# Altium schematic sheet can_12V_step_Ivan.SchDoc
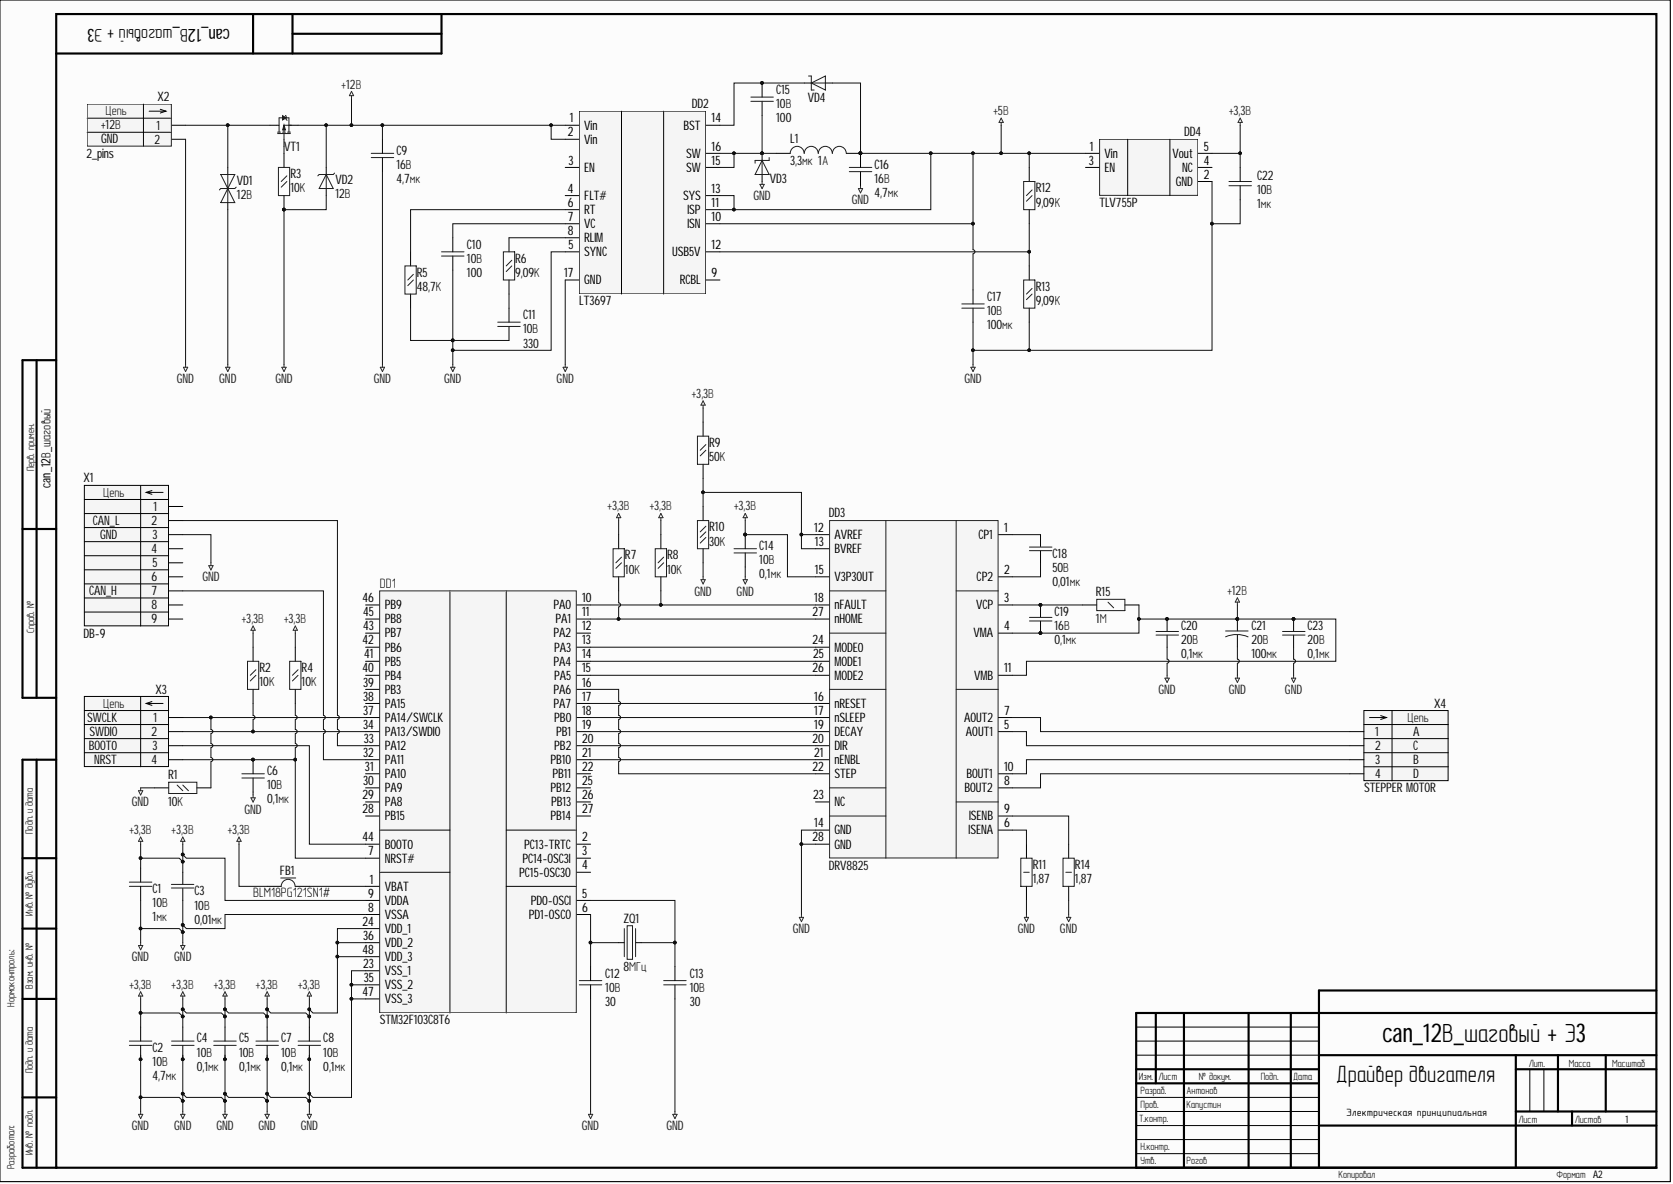
[diagram p0: can_12V_step_Ivan.SchDoc]

## Parts
C1  Capacitance: 1мк  Voltage: 10В  [CH0201C]  (hidden comment: Capacitor)
C2  Capacitance: 4,7мк  Voltage: 10В  [CH0402C]  (hidden comment: Capacitor)
C3  Capacitance: 0,01мк  Voltage: 10В  [CH0402C]  (hidden comment: Capacitor)
C4  Voltage: 10В  [CH0402C]  (hidden comment: Capacitor)
C5  Capacitance: 0,1мк  Voltage: 10В  [CH0402C]  (hidden comment: Capacitor)
C6  Capacitance: 0,1мк  Voltage: 10В  [CH0402C]  (hidden comment: Capacitor)
C7  Capacitance: 0,1мк  Voltage: 10В  [CH0402C]  (hidden comment: Capacitor)
C8  Capacitance: 0,1мк  Voltage: 10В  [CH0402C]  (hidden comment: Capacitor)
C9  Capacitance: 4,7мк  Voltage: 16В  [CH0603C]  (hidden comment: Capacitor)
C10  Voltage: 10В  [CH0201C]  (hidden comment: Capacitor)
C11  Voltage: 10В  [CH0402C]  (hidden comment: Capacitor)
C12  Voltage: 10В  [CH0201C]  (hidden comment: Capacitor)
C13  Voltage: 10В  [CH0201C]  (hidden comment: Capacitor)
C14  Capacitance: 0,1мк  Voltage: 10В  [CH0402C]  (hidden comment: Capacitor)
C15  Voltage: 10В  [CH0201C]  (hidden comment: Capacitor)
C16  Capacitance: 4,7мк  Voltage: 16В  [CH0603C]  (hidden comment: Capacitor)
C17  Capacitance: 100мк  Voltage: 10В  [CH1206C]  (hidden comment: Capacitor)
C18  Capacitance: 0,01мк  Voltage: 50В  [CH0402C]  (hidden comment: Capacitor)
C19  Capacitance: 0,1мк  Voltage: 16В  [CH0402C]  (hidden comment: Capacitor)
C20  Capacitance: 0,1мк  Voltage: 20В  [CH0805C]  (hidden comment: Capacitor)
C21  Capacitance: 100мк  Voltage: 20В  [RB5-10.5]  (hidden comment: Capacitor_Passing)
C22  Capacitance: 1мк  Voltage: 10В  [CH0201C]  (hidden comment: Capacitor)
C23  Capacitance: 0,1мк  Voltage: 20В  [CH0805C]  (hidden comment: Capacitor)
DD1  STM32F103C8T6  [STM32F103 48pins]
DD2  LT3697  [LT3697]
DD3  DRV8825  [DRV8825]
DD4  TLV755P  [SOT23-5]
FB1  Model: BLM18PG121SN1#  [CH0603L]  (hidden comment: Ferrite bead)
L1  Inductance: 3,3мк  Max current: 1А  [CH0805L]
R1  Impedance: 10К  [CH0805R]  (hidden comment: Res_0.125)
R2  Impedance: 10К  [CH0805R]  (hidden comment: Res_0.125)
R3  Impedance: 10К  [CH0402R]  (hidden comment: Res_0.125)
R4  Impedance: 10К  [CH0805R]  (hidden comment: Res_0.125)
R5  Impedance: 48,7К  [CH0805R]  (hidden comment: Res_0.125)
R6  Impedance: 9,09К  [CH0805R]  (hidden comment: Res_0.125)
R7  Impedance: 10К  [CH0805R]  (hidden comment: Res_0.125)
R8  Impedance: 10К  [CH0805R]  (hidden comment: Res_0.125)
R9  Impedance: 50К  [CH0603R]  (hidden comment: Res_0.125)
R10  Impedance: 30К  [CH0201R]  (hidden comment: Res_0.05)
R11  Impedance: 1,87  [CH0603R]  (hidden comment: Res_1)
R12  Impedance: 9,09К  [CH0805R]  (hidden comment: Res_0.125)
R13  Impedance: 9,09К  [CH0805R]  (hidden comment: Res_0.125)
R14  Impedance: 1,87  [CH0603R]  (hidden comment: Res_1)
R15  Impedance: 1М  [CH0805R]  (hidden comment: Res_0.5)
VD1  Clamping Voltage: 12В  [CH0201L]  (hidden comment: TVS-diod_simmetrical)
VD2  Clamping_Voltage: 12В  [DO214AA]  (hidden comment: TVS-diod_directed)
VD3  Diod Schottky  [DO214AA]  (value not drawn)
VD4  Diod Schottky  [SOT23_132]  (value not drawn)
VT1  (no value)  [SOT23_132]
X1  DB-9  2: CAN_L  3: GND  7: CAN_H  [CON: DB-9F]
X2  2_pins  Vcc: +12В  [CON: 163-179PH-EX]
X3  1: SWCLK  2: SWDIO  3: BOOT0  4: NRST  [CON: CWF-4R]  (hidden comment: 4_pins)
X4  STEPPER MOTOR  1: A  2: C  3: B  4: D  [CON: CWF-4R]
ZQ1  Freq: 8МГц  [OSC: 5032]  (hidden comment: Oscillator)

## Nets
+3,3В: C1.2 C2.2 C3.1 C4.1 C5.1 C7.1 C8.1 C14.1 C22.1 DD1.9(VDDA) DD1.24(VDD_1) DD1.36(VDD_2) DD1.48(VDD_3) DD3.15(V3P3OUT) DD4.5(Vout) FB1.1 R2.1 R4.1 R7.1 R8.1 R9.2
+5В: C16.1 C17.1 DD2.10(ISN) DD2.11(ISP) DD2.13(SYS) DD4.1(Vin) L1.2 R12.1 VD4.1
+12В: C9.1 C19.1 C20.2 C21.1 C23.2 DD2.1(Vin) DD2.2(Vin) DD3.4(VMA) DD3.11(VMB) R15.1 VD2.2 VT1.3
GND: C1.1 C2.1 C3.2 C4.2 C5.2 C6.2 C7.2 C8.2 C9.2 C10.2 C11.2 C12.2 C13.2 C14.2 C16.2 C17.2 C20.1 C21.2 C22.2 C23.1 DD1.8(VSSA) DD1.23(VSS_1) DD1.35(VSS_2) DD1.47(VSS_3) DD2.5(SYNC) DD2.17(GND) DD3.14(GND) DD3.28(GND) DD4.2(GND) R1.1 R3.1 R5.2 R10.1 R11.1 R13.2 R14.1 VD1.1 VD2.1 VD3.1 X1.3 X2.2
NetC6_1: C6.1 DD1.7(NRST#) R4.2 X3.4
NetC10_1: C10.1 DD2.7(VC)
NetC11_1: C11.1 R6.2
NetC12_1: C12.1 DD1.6(PD1-OSCO) ZQ1.1
NetC13_1: C13.1 DD1.5(PD0-OSCI) ZQ1.3
NetC15_1: C15.1 DD2.14(BST) VD4.2
NetC15_2: C15.2 DD2.15(SW) DD2.16(SW) L1.1 VD3.2
NetC18_1: C18.1 DD3.2(CP2)
NetC18_2: C18.2 DD3.1(CP1)
NetC19_2: C19.2 DD3.3(VCP) R15.2
NetDD1_1: DD1.1(VBAT) FB1.2
NetDD1_10: DD1.10(PA0) DD3.18(nFAULT) R8.2
NetDD1_11: DD1.11(PA1) DD3.27(nHOME) R7.2
NetDD1_13: DD1.13(PA3) DD3.24(MODE0)
NetDD1_14: DD1.14(PA4) DD3.25(MODE1)
NetDD1_15: DD1.15(PA5) DD3.26(MODE2)
NetDD1_16: DD1.16(PA6) DD3.22(STEP)
NetDD1_17: DD1.17(PA7) DD3.16(nRESET)
NetDD1_18: DD1.18(PB0) DD3.17(nSLEEP)
NetDD1_19: DD1.19(PB1) DD3.19(DECAY)
NetDD1_20: DD1.20(PB2) DD3.20(DIR)
NetDD1_21: DD1.21(PB10) DD3.21(nENBL)
NetDD1_32: DD1.32(PA11) X1.7
NetDD1_33: DD1.33(PA12) X1.2
NetDD1_34: DD1.34(PA13/SWDIO) R2.2 X3.2
NetDD1_37: DD1.37(PA14/SWCLK) R1.2 X3.1
NetDD1_44: DD1.44(BOOT0) X3.3
NetDD2_6: DD2.6(RT) R5.1
NetDD2_8: DD2.8(RLIM) R6.1
NetDD2_12: DD2.12(USB5V) R12.2 R13.1
NetDD3_5: DD3.5(AOUT1) X4.2
NetDD3_6: DD3.6(ISENA) R11.2
NetDD3_7: DD3.7(AOUT2) X4.1
NetDD3_8: DD3.8(BOUT2) X4.4
NetDD3_9: DD3.9(ISENB) R14.2
NetDD3_10: DD3.10(BOUT1) X4.3
NetDD3_12: DD3.12(AVREF) DD3.13(BVREF) R9.1 R10.2
NetR3_2: R3.2 VT1.1
NetVD1_2: VD1.2 VT1.2 X2.1

Not connected: DD1 2(PC13-TRTC) 3(PC14-OSC3I) 4(PC15-OSC3O) 12(PA2) 22(PB11) 25(PB12) 26(PB13) 27(PB14) 28(PB15) 29(PA8) 30(PA9) 31(PA10) 38(PA15) 39(PB3) 40(PB4) 41(PB5) 42(PB6) 43(PB7) 45(PB8) 46(PB9); DD2 3(EN) 4(FLT#) 9(RCBL); DD3 23(NC); DD4 3(EN) 4(NC); X1 1 4 5 6 8 9

## Library data of the parts
C1 C22 = Capacitor: Manufacture: Murata Electronics; Model: GRM033C81A105ME05E; Case: 0201; Component Kind: Standard; HelpURL: https://ru.mouser.com/ProductDetail/Murata-Electronics/GRM033C81A105ME05E?qs=sGAEpiMZZMs0AnBnWHyRQN7%2fAA2D2lPPXfhIkJ3ChWM%252bNp28BKy3Ug%3d%3d; Library Name: GOST_Capacitors.SchLib
C2 = Capacitor: Manufacture: Murata Electronics; Model: ZRB15XR61A475KE01D; Case: 0402; Component Kind: Standard; HelpURL: https://ru.mouser.com/ProductDetail/Murata-Electronics/ZRB15XR61A475KE01D?qs=sGAEpiMZZMs0AnBnWHyRQO9VYadaKTO%252beZnE9zFUsbv4FmCibz4ZfQ%3d%3d; Library Name: GOST_Capacitors.SchLib
C3 = Capacitor: Manufacture: Yageo; Model: CC0402MRX7R6BB103; Case: 0402; Component Kind: Standard; HelpURL: https://ru.mouser.com/ProductDetail/Yageo/CC0402MRX7R6BB103?qs=sGAEpiMZZMs0AnBnWHyRQCF1lTWZ1EBLC11KxQK9NtY%3D; Library Name: GOST_Capacitors.SchLib
C4 = Capacitor: Manufacture: AVX; Capacitance: 0,1мк; Model: 0402ZD103KAT2A; Case: 0402; Component Kind: Standard; HelpURL: https://ru.mouser.com/ProductDetail/AVX/0402ZD103KAT2A?qs=sGAEpiMZZMs0AnBnWHyRQKdiqyDPVQdAu74W%2fwwRq8A%3d; Library Name: GOST_Capacitors.SchLib
C5-C8 C14 = Capacitor: Manufacture: AVX; Model: 0402ZD103KAT2A; Case: 0402; Component Kind: Standard; HelpURL: https://ru.mouser.com/ProductDetail/AVX/0402ZD103KAT2A?qs=sGAEpiMZZMs0AnBnWHyRQKdiqyDPVQdAu74W%2fwwRq8A%3d; Library Name: GOST_Capacitors.SchLib
C9 C16 = Capacitor: Manufacture: Murata Electronics; Model: GRM188R61C475KAAJD; Case: 0603; Component Kind: Standard; HelpURL: https://ru.mouser.com/ProductDetail/Murata-Electronics/GRM188R61C475KAAJD?qs=sGAEpiMZZMs0AnBnWHyRQHS%2f3XE0oMwxE3tFP4oDIiY%3d; Library Name: GOST_Capacitors.SchLib
C10 C15 = Capacitor: Manufacture: KEMET; Capacitance: 100; Model: C0201C101K8GACTU; Case: 0201; Component Kind: Standard; HelpURL: https://ru.mouser.com/ProductDetail/KEMET/C0201C101K8GACTU?qs=sGAEpiMZZMs0AnBnWHyRQB5KqWiNAtmEn9gsTWOdKS%252b7RrFbxqtALA%3d%3d; Library Name: GOST_Capacitors.SchLib
C11 = Capacitor: Manufacture: Vishay / Vitramon; Capacitance: 330; Model: VJ0402Y331MXQCW1BC; Case: 0402; Component Kind: Standard; HelpURL: https://ru.mouser.com/ProductDetail/Vishay-Vitramon/VJ0402Y331MXQCW1BC?qs=sGAEpiMZZMs0AnBnWHyRQHefeAIkSpb%2fKjIwGvKZvs8%3d; Library Name: GOST_Capacitors.SchLib
C12 C13 = Capacitor: Manufacture: KEMET; Capacitance: 30; Model: CBR02C308B8GAC; Case: 0201; Component Kind: Standard; HelpURL: https://ru.mouser.com/ProductDetail/KEMET/CBR02C308B8GAC?qs=sGAEpiMZZMs0AnBnWHyRQKJCfzG%2fi9wHnix1yOwfSPhOUzhoHh%252bTpQ%3d%3d; Library Name: GOST_Capacitors.SchLib
C17 = Capacitor: Manufacture: TDK; Model: C3216X5R1A107M160AC; Case: 1206; Component Kind: Standard; HelpURL: https://ru.mouser.com/ProductDetail/TDK/C3216X5R1A107M160AC?qs=sGAEpiMZZMs0AnBnWHyRQEWjzA2rN6H9eWVfGykJv7Q%3d; Library Name: GOST_Capacitors.SchLib
C18 = Capacitor: Manufacture: Yageo; Model: AC0402KRX7R9BB103; Case: 0402; Component Kind: Standard; HelpURL: https://ru.mouser.com/ProductDetail/Yageo/AC0402KRX7R9BB103?qs=sGAEpiMZZMs0AnBnWHyRQBZcF7Vf94SCHXtsGWcqG94cFjQPe22zAg%3D%3D; Library Name: GOST_Capacitors.SchLib
C19 = Capacitor: Manufacture: Yageo; Model: CC0402KPX5R7BB104; Case: 0402; Component Kind: Standard; HelpURL: https://ru.mouser.com/ProductDetail/Yageo/CC0402KPX5R7BB104?qs=sGAEpiMZZMs0AnBnWHyRQBZcF7Vf94SC0cALCY4FDZWppIvzFqHKwA%3D%3D; Library Name: GOST_Capacitors.SchLib
C20 C23 = Capacitor: Manufacture: Vishay / Sprague; Model: TMCP1D104MTRF; Case: 0805; Component Kind: Standard; HelpURL: https://ru.mouser.com/ProductDetail/Vishay-Sprague/TMCP1D104MTRF?qs=sGAEpiMZZMsh%252B1woXyUXj%252Bp8lp8l%2FqQ2aRrDnwXAyQE%3D; Library Name: GOST_Capacitors.SchLib
C21 = Capacitor_Passing: Manufacture: KEMET; Model: A755KS107M1DAAE020; Case: Bulk 8х12 mm; Component Kind: Standard; HelpURL: https://ru.mouser.com/ProductDetail/KEMET/A755KS107M1DAAE020?qs=sGAEpiMZZMsh%252B1woXyUXj9o1%2FkKtBj0MuWI8g1mDmRQ%3D; Library Name: GOST_Capacitors.SchLib
DD1 = STM32: Manufacture: STMicroelectronics; Case: LQFP48; Component Kind: Standard; HelpURL: https://www.st.com/en/microcontrollers-microprocessors/stm32f103c8.html; Model: STM32F103C8T6; Library Name: GOST_lib_devices.SchLib
DD2 = LT3697: 6~18 to 5; Manufacture: Analog Devices; Component Kind: Standard; HelpURL: https://www.analog.com/en/products/lt3697.html; Model: LT3697; Library Name: GOST_lib_devices.SchLib
DD3 = DRV8825: Manufacture: Texas Instruments; Case: TSSOP; Component Kind: Standard; HelpURL: http://www.ti.com/product/DRV8825; Model: DRV8825; Library Name: GOST_lib_devices.SchLib
DD4 = LDO +3.3V: Manufacture: Texas Instruments; Case: SOT-23; Component Kind: Standard; HelpUrL: http://www.ti.com/product/TLV755P?keyMatch=TLV755P&tisearch=Search-EN-Everything; Model: TLV755P; Library Name: GOST_lib_devices.SchLib
FB1 = Ferrite bead: Manufacture: murata; Impedance: 120; Max current: 2A; Case: 0603; Component Kind: Standard; HelpURL: https://www.murata.com/en-us/products/productdetail?partno=BLM18PG121SN1%23; Library Name: GOST_Inductors.SchLib
L1 = Inductor: Manufacture: Murata Electronics; Impedance: 150м; Model: LQM21PZ3R3MGRD; Case: 0805; Component Kind: Standard; HelpURL: https://ru.mouser.com/ProductDetail/Murata-Electronics/LQM21PZ3R3MGRD?qs=sGAEpiMZZMsg%252by3WlYCkU2iuTbKTbm6Zxs6Ix4GkUPA%3d; Library Name: GOST_Inductors.SchLib
R1 R2 R4 R6-R8 R12 R13 = Res_0.125: Manufacture: Panasonic Industrial Devices; Model: ERJ-6ENF9091V; Case: 0805; Component Kind: Standard; HelpURL: https://ru.mouser.com/ProductDetail/Panasonic-Industrial-Devices/ERJ-6ENF9091V?qs=sGAEpiMZZMvdGkrng054tx7%2f6%252bNA3LAJZkxjwUnWjWM%3d; Library Name: GOST_Resistors.SchLib
R3 = Res_0.125: Manufacture: Yageo; Model: AC0402FR-7W10KL; Case: 0402; Component Kind: Standard; HelpURL: https://ru.mouser.com/ProductDetail/Yageo/AC0402FR-7W10KL?qs=sGAEpiMZZMvdGkrng054txbN%2f5LBcxld3gyqgmBuiXRPLjwmdNqO3A%3d%3d; Library Name: GOST_Resistors.SchLib
R5 = Res_0.125: Manufacture: Panasonic Industrial Devices; Model: ERJ-6ENF4872V; Case: 0805; Component Kind: Standard; HelpURL: https://ru.mouser.com/ProductDetail/Panasonic-Industrial-Devices/ERJ-6ENF4872V?qs=sGAEpiMZZMvdGkrng054tx7%2f6%252bNA3LAJLLBsBMFOrm0%3d; Library Name: GOST_Resistors.SchLib
R9 = Res_0.125: Manufacture: Yageo; Model: RT0603BRD0750KL; Power: 100мВ; Case: 0603; Component Kind: Standard; HelpURL: https://ru.mouser.com/ProductDetail/Yageo/RT0603BRD0750KL?qs=sGAEpiMZZMtlubZbdhIBIEgN9MQql2m6ewcteVFRdgU%3D; Library Name: GOST_Resistors.SchLib
R10 = Res_0.05: Manufacture: Vishay; Model: CRCW020130K0FNED; Power: 0,05В; Case: 0201; Component Kind: Standard; HelpURL: https://ru.mouser.com/ProductDetail/Vishay/CRCW020130K0FNED?qs=sGAEpiMZZMtlubZbdhIBIJshr8wLt%252BurnrZICO32FEA%3D; Library Name: GOST_Resistors.SchLib
R11 R14 = Res_1: Manufacture: KOA Speer; Model: WK73S2ATTD1R87F; Power: 1Вт; Case: 0508; Component Kind: Standard; HelpURL: https://ru.mouser.com/ProductDetail/KOA-Speer/WK73S2ATTD1R87F?qs=sGAEpiMZZMtlubZbdhIBIIR71ikvQRXLAqdbfLeoe5g%3D; Library Name: GOST_Resistors.SchLib
R15 = Res_0.5: Manufacture: KOA Speer; Model: SG73G2ATTD1004D; Case: 0805; Component Kind: Standard; HelpURl: https://ru.mouser.com/ProductDetail/KOA-Speer/SG73G2ATTD1004D?qs=sGAEpiMZZMvdGkrng054tz1y1XEHv7sNqdEHfKLpAGs6ZpwyMDczVg%3d%3d; Library Name: GOST_Resistors.SchLib
VD1 = TVS-diod_simmetrical: Manufacturer: Littelfuse; Model: SP3022-01WTG; Case: 0201; Component Kind: Standard; HelpURL: https://ru.mouser.com/ProductDetail/Littelfuse/SP3022-01WTG?qs=sGAEpiMZZMsItbzKdwyIez7NGmqnzL8h47KYC2e%252bM%2f4NVETz1A7KGA%3d%3d; Library Name: GOST_Diodes.SchLib
VD2 = TVS-diod_directed: Manufacturer: Bourns; Model: CD214B-T7.0ALF; Case: DO-214AA-2; Component Kind: Standard; HelpURL: https://ru.mouser.com/ProductDetail/Bourns/CD214B-T70ALF?qs=sGAEpiMZZMsItbzKdwyIexL102H4jKkFOiaKL2EcEgo%3d; Library Name: GOST_Diodes.SchLib
VD3 = Diod Schottky: Manufacturer: Rectron; If: 3А; Model: FM5822B-W; Vrrm: 40В; Component Kind: Standard; HelpURL: https://ru.mouser.com/ProductDetail/Rectron/FM5822B-W?qs=sGAEpiMZZMtQ8nqTKtFS%2fGuc2LbLh1yNV0sywe9%2fy3k%3d; Library Name: GOST_Diodes.SchLib
VD4 = Diod Schottky: Manufacturer: Toshiba; If: 200мА; Model: 1SS374(TE85L,F); Vrrm: 15В; Component Kind: Standard; HelpURL: https://ru.mouser.com/ProductDetail/Toshiba/1SS374TE85LF?qs=sGAEpiMZZMtQ8nqTKtFS%2fOl9H8JU2QXlyYvmz0vcRmull8rlMQPxpQ%3d%3d; Library Name: GOST_Diodes.SchLib
VT1 = P-Channel: Manufacture: Diodes Incorporated; Id: 2,4А; Model: DMG2301LK-7; Vgs: 300мВ; Vsd: 20В; Component Kind: Standard; HelpURL: https://ru.mouser.com/ProductDetail/Diodes-Incorporated/DMG2301LK-7?qs=sGAEpiMZZMshyDBzk1%2fWi3VK%2fMVeCy7TGgocA8mg7FfG8rajAhy0Kw%3d%3d; Library Name: GOST_Transistors.SchLib
X1 = 9_pins: Component Kind: Standard; HelpURL: https://www.digikey.com/product-detail/en/assmann-wsw-components/A-DS-09-LL-Z/AE10972-ND/1241781; Model: DB-9; Library Name: GOST_lib_connectors.SchLib
X3 = 4_pins: Manufacture: Omron Electronics; Model: XN2D-4471; Component Kind: Standard; HelpURL: https://ru.mouser.com/ProductDetail/Omron-Electronics/XN2D-4471?qs=sGAEpiMZZMvd2mIcYG7oaC0TeQEBnk0JvHtcE4UuDS0%3D; Library Name: GOST_lib_connectors.SchLib
X4 = 4_pins: Bipolar Stepper Motor 200 Step 0.35A 12VDC; Manufacture: Adafruit Industries LLC; ManufactureConnector: Omron Electronics; Model: 324; ModelConnector: XN2D-4471; Case: Square - 1.654" x 1.654" (42.00mm x 42.00mm); Component Kind: Standard; HelpURL: https://www.digikey.com/product-detail/en/adafruit-industries-llc/324/1528-1062-ND/5022791; HelpURLConnector: https://ru.mouser.com/ProductDetail/Omron-Electronics/XN2D-4471?qs=sGAEpiMZZMvd2mIcYG7oaC0TeQEBnk0JvHtcE4UuDS0%3D; Library Name: GOST_lib_connectors.SchLib
ZQ1 = Oscillator: Manufacture: CTS Electronic Components; Model: 636L3C008M00000; Case: 5x3,2mm; Component Kind: Standard; HelpURL: https://ru.mouser.com/ProductDetail/CTS-Electronic-Components/636L3C008M00000?qs=sGAEpiMZZMt8zWNA7msRCjl4WCD9T6KEFNudNQm%252BeAU%3D; Library Name: GOST_Miscelanuious.SchLib
X2 = 2_pins: Component Kind: Standard; HelpURL: https://ru.farnell.com/gct-global-connector-technology/dcj250-10-a-k1-k/dc-power-jack-5a-20vdc-black/dp/2524130?st=power%20jack; Library Name: GOST_lib_connectors.SchLib
